# Altium schematic sheet pw_dist_v1.2_s2.SchDoc(pw_dist_v1.2_s2)
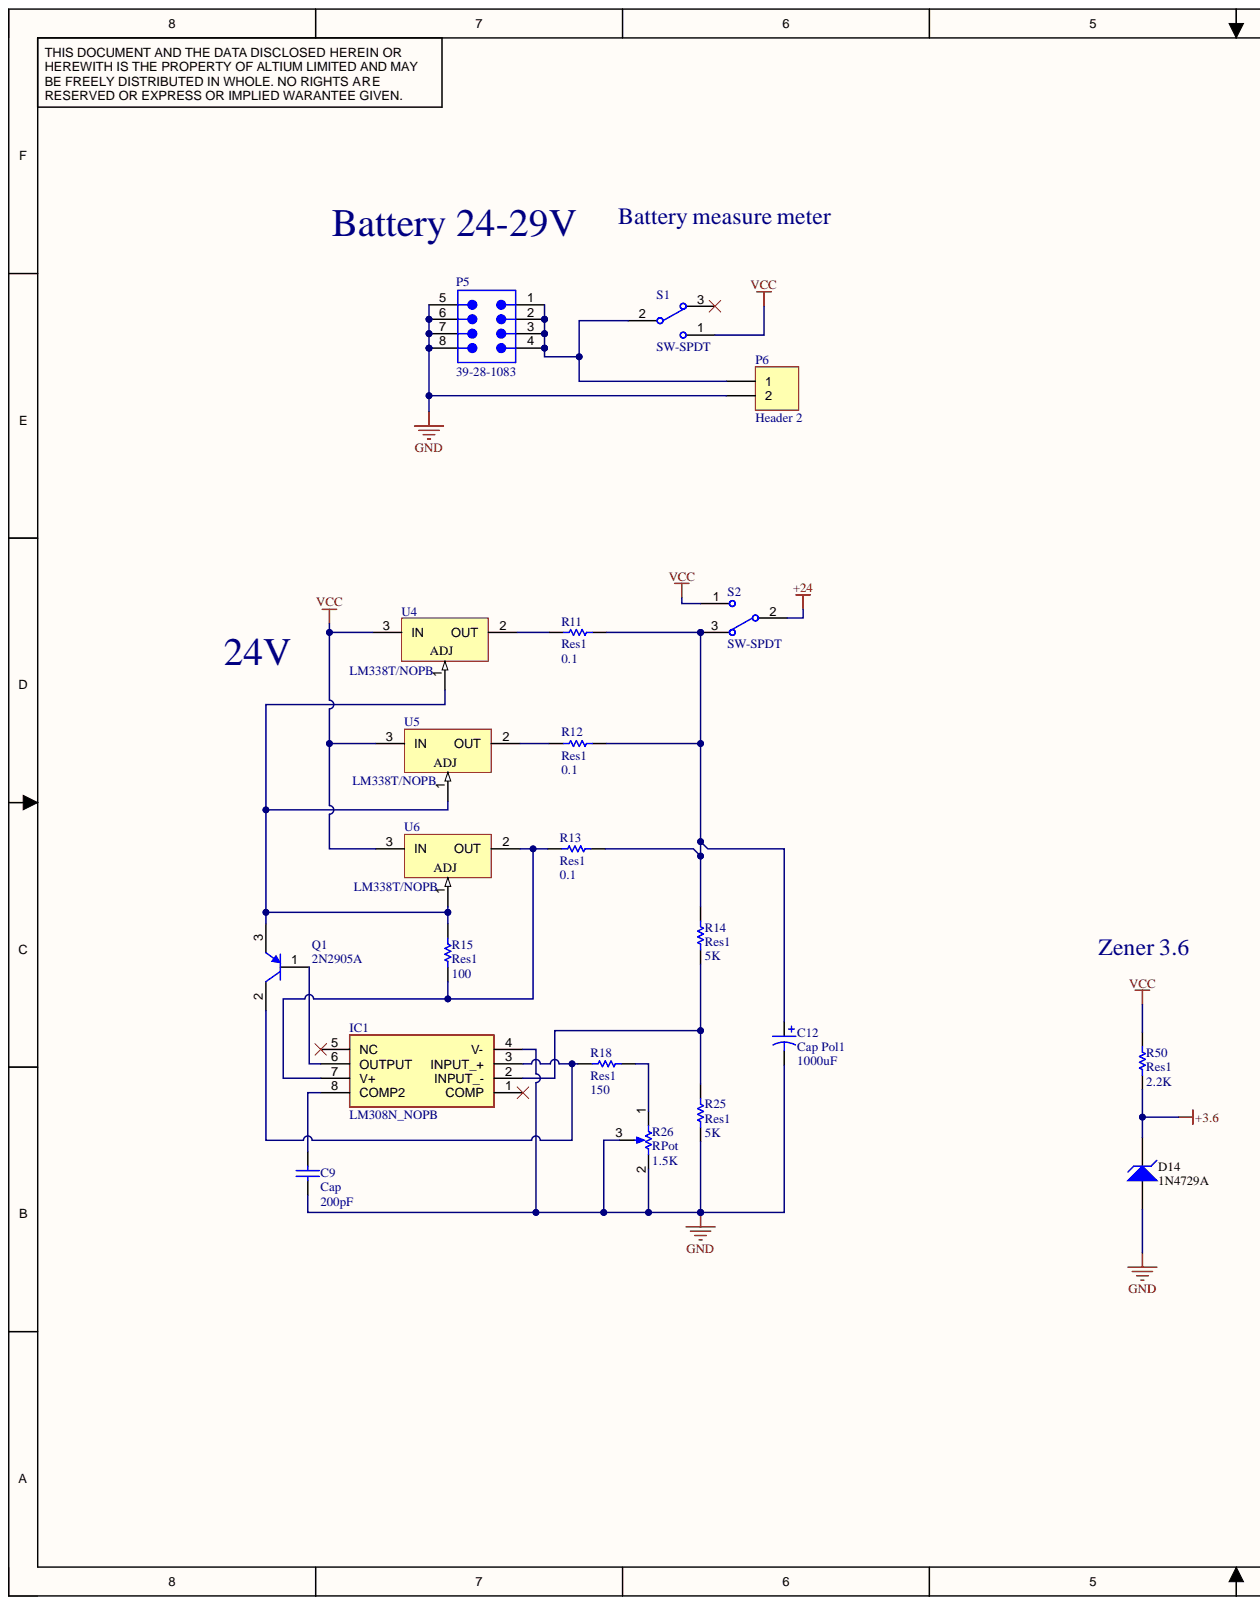
[diagram p0: pw_dist_v1.2_s2.SchDoc, left]
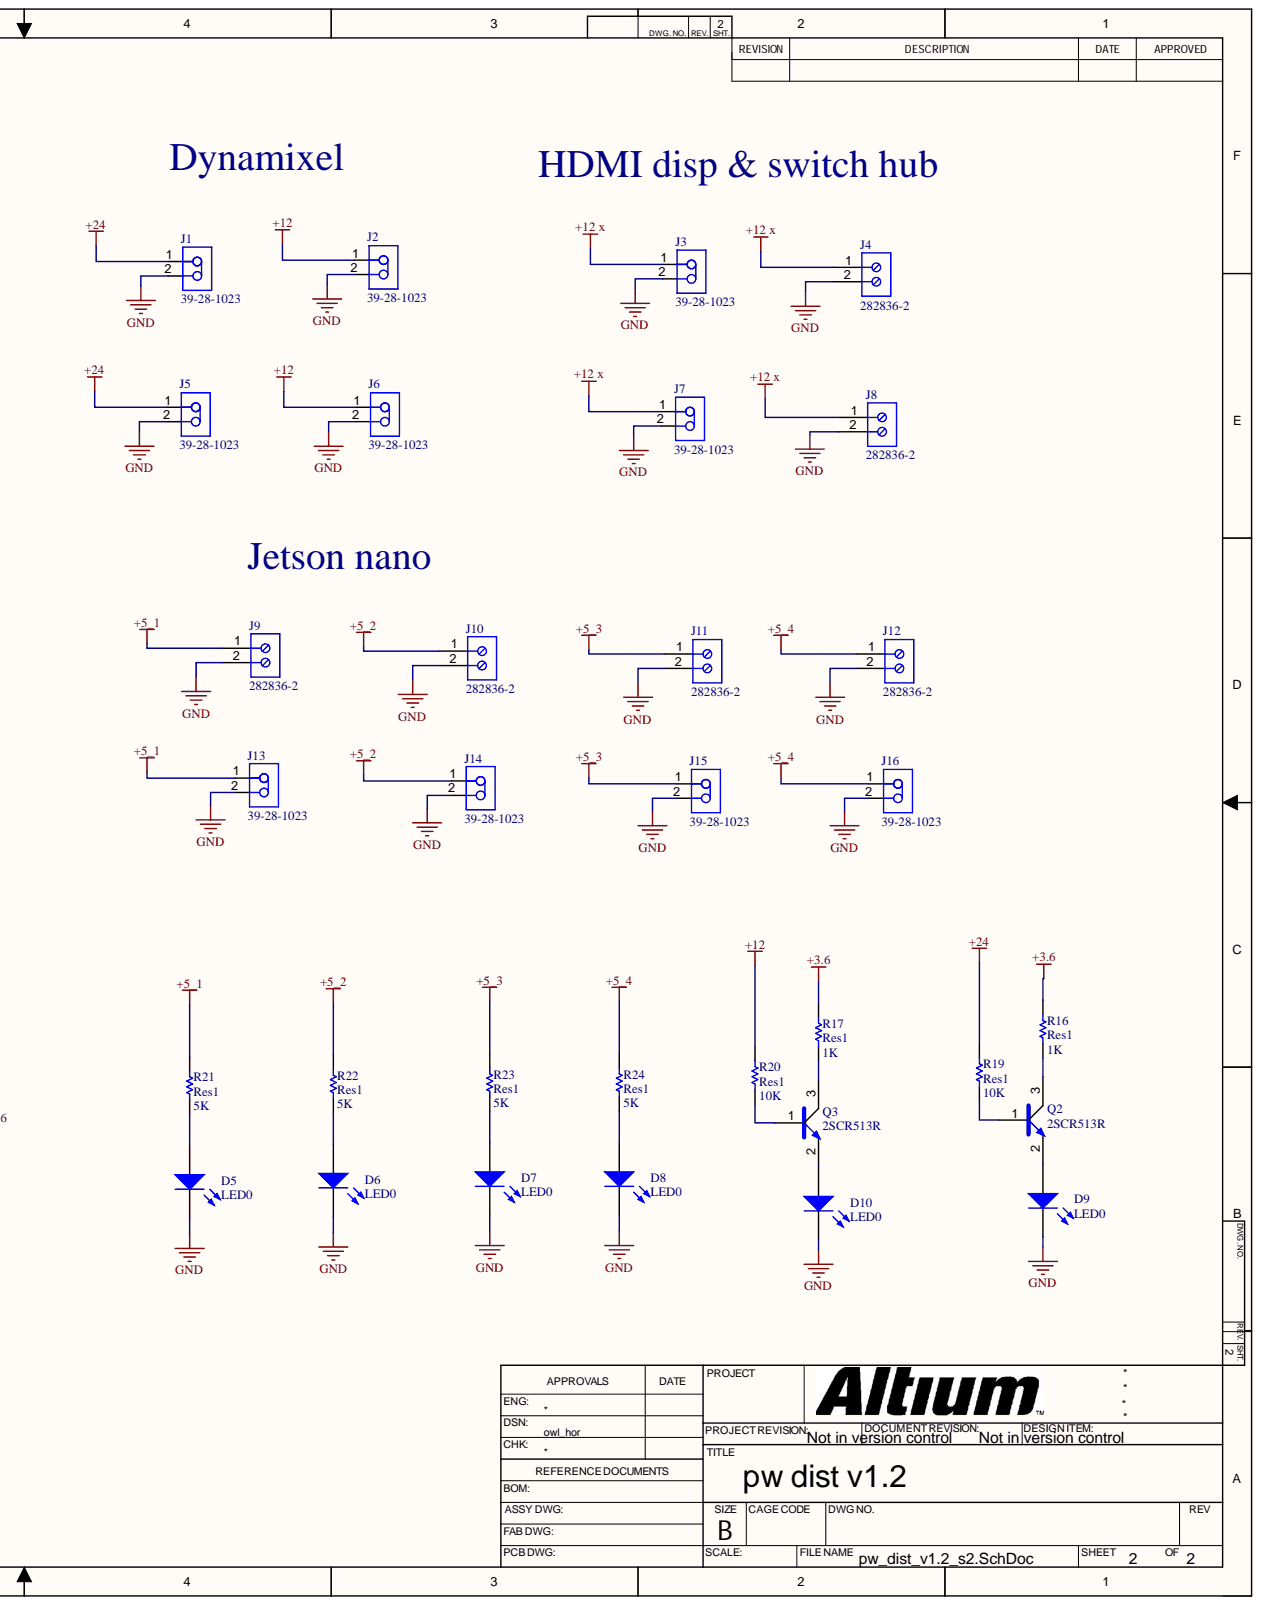
[diagram p1: pw_dist_v1.2_s2.SchDoc, right]

## Parts
C9  Cap  value 200pF  [RAD-0.1]
C12  Cap Pol1  value 1000uF  [RB7.6-15]
D5  LED0  [TY-A1206PG1]
D6  LED0  [TY-A1206PG1]
D7  LED0  [TY-A1206PG1]
D8  LED0  [TY-A1206PG1]
D9  LED0  [TY-A1206PG1]
D10  LED0  [TY-A1206PG1]
D14  1N4729A  [FAIR-DO-41-2_V]
IC1  LM308N_NOPB  [DIP787W53P254L982H508Q8N]
J1  39-28-1023  [MOLX-39-28-1023-2_V]
J2  39-28-1023  [MOLX-39-28-1023-2_V]
J3  39-28-1023  [MOLX-39-28-1023-2_V]
J4  282836-2  [TECO-282836-2_V]
J5  39-28-1023  [MOLX-39-28-1023-2_V]
J6  39-28-1023  [MOLX-39-28-1023-2_V]
J7  39-28-1023  [MOLX-39-28-1023-2_V]
J8  282836-2  [TECO-282836-2_V]
J9  282836-2  [TECO-282836-2_V]
J10  282836-2  [TECO-282836-2_V]
J11  282836-2  [TECO-282836-2_V]
J12  282836-2  [TECO-282836-2_V]
J13  39-28-1023  [MOLX-39-28-1023-2_V]
J14  39-28-1023  [MOLX-39-28-1023-2_V]
J15  39-28-1023  [MOLX-39-28-1023-2_V]
J16  39-28-1023  [MOLX-39-28-1023-2_V]
P5  39-28-1083  [MOLX-39-28-1083_V]
P6  Header 2  [HDR1X2]
Q1  2N2905A  [STM-TO-39-3]
Q2  2SCR513R  [2SCR513R]
Q3  2SCR513R  [2SCR513R]
R11  Res1  value 0.1  [Axial 0.65]
R12  Res1  value 0.1  [Axial 0.65]
R13  Res1  value 0.1  [Axial 0.65]
R14  Res1  value 5K  [Axial 0.65]
R15  Res1  value 100  [AXIAL-0.3]
R16  Res1  value 1K  [Res_SMD_3mm]
R17  Res1  value 1K  [Res_SMD_3mm]
R18  Res1  value 150  [AXIAL-0.3]
R19  Res1  value 10K  [Res_SMD_3mm]
R20  Res1  value 10K  [Res_SMD_3mm]
R21  Res1  value 5K  [Res_SMD_3mm]
R22  Res1  value 5K  [Res_SMD_3mm]
R23  Res1  value 5K  [Res_SMD_3mm]
R24  Res1  value 5K  [Res_SMD_3mm]
R25  Res1  value 5K  [Axial 0.65]
R26  RPot  value 1.5K  [VR5]
R50  Res1  value 2.2K  [AXIAL-0.3]
S1  SW-SPDT  [SS-12F45]
S2  SW-SPDT  [SS-12F45]
U4  LM338T/NOPB  [NDE0003A]
U5  LM338T/NOPB  [NDE0003A]
U6  LM338T/NOPB  [NDE0003A]

## Nets
+3.6: D14.2 R16.2 R17.2 R50.1
+5_1: J9.1 J13.1 R21.2
+5_2: J10.1 J14.1 R22.2
+5_3: J11.1 J15.1 R23.2
+5_4: J12.1 J16.1 R24.2
+12: J2.1 J6.1 R20.2
+12 x: J3.1 J4.1 J7.1 J8.1
+24: J1.1 J5.1 R19.2 S2.2
GND: C9.1 C12.2 D5.2 D6.2 D7.2 D8.2 D9.2 D10.2 D14.1 IC1.4(V-) J1.2 J2.2 J3.2 J4.2 J5.2 J6.2 J7.2 J8.2 J9.2 J10.2 J11.2 J12.2 J13.2 J14.2 J15.2 J16.2 P5.5 P5.6 P5.7 P5.8 P6.2 R25.1 R26.2 R26.3
VCC: R50.2 S1.1 S2.1 U4.3 U5.3 U6.3
NetC9_2: C9.2 IC1.8(COMP2)
NetC12_1: C12.1 R11.1 R12.1 R13.1 R14.2 S2.3
NetD5_1: D5.1 R21.1
NetD6_1: D6.1 R22.1
NetD7_1: D7.1 R23.1
NetD8_1: D8.1 R24.1
NetD9_1: D9.1 Q2.2
NetD10_1: D10.1 Q3.2
NetIC1_2: IC1.2(INPUT_-) R14.1 R25.2
NetIC1_3: IC1.3(INPUT_+) Q1.2 R18.2
NetIC1_6: IC1.6(OUTPUT) Q1.1
NetIC1_7: IC1.7(V+) R13.2 R15.1 U6.2
NetP5_1: P5.1 P5.2 P5.3 P5.4 P6.1 S1.2
NetQ1_3: Q1.3 R15.2 U4.1 U5.1 U6.1
NetQ2_1: Q2.1 R19.1
NetQ2_3: Q2.3 R16.1
NetQ3_1: Q3.1 R20.1
NetQ3_3: Q3.3 R17.1
NetR11_2: R11.2 U4.2
NetR12_2: R12.2 U5.2
NetR18_1: R18.1 R26.1

Not connected: IC1 1(COMP) 5(NC); S1 3

## Library data of the parts
C9 = Cap: Capacitor; Component Kind: Standard; LatestRevisionDate: 17-Jul-2002; LatestRevisionNote: Re-released for DXP Platform.; Library Name: Miscellaneous Devices.IntLib; PackageDescription: Radial Cap, Thru-Hole; 2 Leads; 0.3 in Pin Spacing; PackageReference: RAD-0.3; Published: 8-Jun-2000; Signal Integrity: Cap; Simulation: CAP; Publisher: Altium Limited
C12 = Cap Pol1: Polarized Capacitor (Radial); Component Kind: Standard; LatestRevisionDate: 17-Jul-2002; LatestRevisionNote: Re-released for DXP Platform.; Library Name: Miscellaneous Devices.IntLib; PackageDescription: Polarized Capacitor (Radial); 2 Leads; PackageReference: RB7.6-15; Published: 8-Jun-2000; Signal Integrity: Cap Pol; Simulation: CAP; Publisher: Altium Limited
D5-D10 = LED0: Typical INFRARED GaAs LED; Component Kind: Standard; LatestRevisionDate: 08-Jul-2005; LatestRevisionNote: Mech Layer 1 removed.; Library Name: Miscellaneous Devices.IntLib; PackageDescription: LED; 2 Leads; PackageReference: LED-0; Published: 8-Jun-2000; Simulation: LED0; Publisher: Altium Limited
D14 = CMP-0892-00027-1: Zener Diode, 3.6V, 1W, 5%, -65 to 200 degC, 2-Pin DO-41, Body 2.72 x 5.2 mm, RoHS; Manufacturer: Fairchild Semiconductor; IR (uA): 100; PD (W): 1; Test Current IZ (mA): 69; VZ (Max.) (V): 3.78; VZ (Min.) (V): 3.42; ZZ (Max) (ohm): 10; Component Kind: Standard; ComponentLink1Description: Manufacturer URL; ComponentLink1URL: http://www.fairchildsemi.com/; ComponentLink2Description: Datasheet; ComponentLink2URL: http://www.fairchildsemi.com/ds/1N/1N4744A.pdf; ComponentLink3Description: Package Specification; ComponentLink3URL: http://www.fairchildsemi.com/dwg/DO/DO41A.pdf; DatasheetVersion: Rev. H3; Library Name: Altium Content Vault; Mounting Technology: Through Hole; Operating and Storage Temperature Range: -65 to 200 degC; PackageDescription: 2-Pin Axial leaded, Body 2.72 x 5.2 mm, Lead Spread 25.4 mm; PackageReference: DO-41-2; PackageVersion: Rev. 2; PartNumber: 1N4729A; RoHS: TRUE; Tolerance: 5%
IC1 = LM308N_NOPB: Integrated Circuit; Manufacturer_Name: Texas Instruments; Manufacturer_Part_Number: LM308N/NOPB; Component Kind: Standard; Datasheet Link: http://www.mit.edu/~6.301/LM108.pdf; Height: 5.08mm; Mouser Part Number: N/A; Mouser Price/Stock: https://www.mouser.com/Search/Refine.aspx?Keyword=N%2FA
J1-J3 J5-J7 J13-J16 = CMP-2000-05244-1: Female Header, Pitch 4.2 mm, 1 x 2 Position, Height 12.8 mm, Tail Length 3.5 mm, -40 to 105 degC, RoHS; Manufacturer: Molex; Connector Body Material: –; Connector Mounting: PCB; Connector Type: RectangularPower; Contact Termination: Solder; Current Rating: 13A; Mounting Orientation: Straight; No. of Contacts: 4; No. of Poles: 2; No. of Rows: 1; Pitch Spacing: 4.2mm; Voltage Rating V AC: 600V; Working Voltage: 250V; Colour: Clear; Component Kind: Standard; Connector Insert Type: Header; Connector Mounting Orientation: PCB; Contact Gender: –; Contact Material: Brass; Contact Plating: Tin; Datasheet URL: https://datasheet.ciiva.com/11746/0900766b80460d9e-11746456.pdf; Datasheet Version: Rev. F, 05/2004; Flammability Rating: UL94V-2; Gender: Header; Lead Spacing: 4.2mm; Library Name: Altium Content Vault; Manufacturer URL: http://www.molex.com/; Mounting Type: PCBoard; MSL: –; No. of Ways: 2; Series: Mini-FitJr; SVHC: –; Termination Method: Solder
J4 J8-J12 = CMP-1684-00017-1: Female Header, Pitch 5 mm, 1 x 2 Position, Height 10 mm, Tail Length 3.5 mm, Rohs, Bulk; Manufacturer: TE Connectivity; 3D Model: 282836-2.pdf; Clamp Material - Plating: Brass-NickelPlated; Current: 13.5A; Dynamic Catalog: BuchananSeries; Featured Product: EurostyleTerminalBlockConnectors; Mating Orientation: HorizontalwithBoard; Number of Levels: 1; Other Names: 5ULV-02B  A98076; Pitch: 0.197"(5.00mm); Positions Per Level: 2; Product Training Modules: Wire-to-BoardEurostyleTerminalBlocks; Screw Thread: M3; Torque - Screw: 0.68Nm(6Lb-In); Voltage: 300V; Wire Gauge: 16-30AWG; Wire Termination: Screw-RisingCageClamp; Category: Connectors,Interconnects; Color: Green; Component Kind: Standard; Contact Material - Plating: Brass-TinPlated; Datasheet URL: http://www.te.com.cn/commerce/DocumentDelivery/DDEController?Action=showdoc&DocId=Catalog+Section%7F1308389_EUROSTYLE_TERMINAL_BLOCKS%7F0607%7Fpdf%7FEnglish%7FENG_CS_1308389_EUROSTYLE_TERMINAL_BLOCKS_0607.pdf%7F282836-2; Family: TerminalBlocks-WiretoBoard; Features: Interlocking(Side); Housing Material: Polyamide(PA66),Nylon6/6; Library Name: Altium Content Vault; Manufacturer URL: http://www.te.com; Mounting Type: ThroughHole; Package Description: Female Header, Pitch 5 mm, 1 x 2 Position, Height 10 mm, Tail Length 3.5 mm; Package Reference: THD; Packaging: Bulk; RoHS Information: 282836-2StatementofCompliance; Screw Material - Plating: Brass-NickelPlated; Series: Buchanan; Standard Package: 300
P5 = CMP-1594-00002-1: 4.2 mm Pitch Mini-Fit Jr.Power Connector, Vertical, Through Hole, 8 Circuits, -40 to 105 degC, RoHS, Bag; No. of Positions: 8; No. of Rows: 2; Pitch: 4.2 mm; Component Kind: Standard; ComponentLink1Description: Manufacturer URL; ComponentLink1URL: http://www.molex.com/; ComponentLink2Description: Datasheet; ComponentLink2URL: http://www.molex.com/webdocs/datasheets/pdf/en-us/0039281083_PCB_HEADERS.pdf; ComponentLink3Description: Package Specification; ComponentLink3URL: http://www.molex.com/pdm_docs/sd/039281083_sd.pdf; Library Name: Altium Content Vault; Mounting Technology: Surface Mount; Orientation: Vertical; PackageDescription: 8-Pin PCB Header, Vertical, Pitch 4.2 mm, Through Hole; PackageVersion: Rev. F, 06/2009; Packing: Bag; PartNumber: 39-28-1083; RoHS: TRUE; Temperature Max: 105 degC; Temperature Min: -40 degC
P6 = Header 2: Header, 2-Pin; Component Kind: Standard; LatestRevisionDate: 17-Jul-2002; LatestRevisionNote: Re-released for DXP Platform.; Library Name: Miscellaneous Connectors.IntLib; Signal Integrity: Connector; Publisher: Altium Limited
Q1 = CMP-2000-05560-1: Small Singal PNP Transistor, 200 MHz, -65 to 175 degC, 3-Pin TO-39, RoHS; Manufacturer: STMicroelectronics; Collector Emitter Voltage V(br)ceo: -60V; DC Collector Current: -600mA; DC Current Gain hFE: 50hFE; No. of Pins: 3Pins; Power Dissipation Pd: 600mW; Transition Frequency ft: 200MHz; Automotive Qualification Standard: -; Component Kind: Standard; Datasheet URL: https://datasheet.ciiva.com/15139/getdatasheetpartid-82818-15139087.pdf; Datasheet Version: 02/2003; Library Name: Altium Content Vault; Manufacturer URL: http://www.st.com/; Mounting Technology: ThroughHole; Operating Temperature Max: 175°C; Package Description: 3-Pin Transistor Outlines Package, Dia 9.4 mm, Height 6.6 mm; Package Reference: TO-39-3; Product Range: -; Transistor Case Style: TO-39; Transistor Polarity: PNP
Q2 Q3 = 2SCR513R: NPN 10A 50V Middle power Transistor; Component Kind: Standard; Library Name: Schlib1.SchLib
R11-R25 R50 = Res1: Resistor; Component Kind: Standard; LatestRevisionDate: 17-Jul-2002; LatestRevisionNote: Re-released for DXP Platform.; Library Name: Miscellaneous Devices.IntLib; PackageDescription: Axial Device, Thru-Hole; 2 Leads; 0.3 in Pin Spacing; PackageReference: AXIAL-0.3; Published: 8-Jun-2000; Signal Integrity: Res; Simulation: RESISTOR; Publisher: Altium Limited
R26 = RPot: Potentiometer; Set Position: 0.5; Sim Note: Enter the Resistance value and the set point into parameter fields.; Component Kind: Standard; LatestRevisionDate: 17-Jul-2002; LatestRevisionNote: Re-released for DXP Platform.; Library Name: Miscellaneous Devices.IntLib; PackageDescription: Variable Resistor, Thru-Hole; 3 Leads; PackageReference: VR5; Published: 8-Jun-2000; Simulation: POT; Publisher: Altium Limited
S1 S2 = SW-SPDT: SPDT Subminiature Toggle Switch, Right Angle Mounting, Vertical Actuation; Component Kind: Standard; ComponentLink1Description: Manufacturer Link; ComponentLink1URL: http://www.apem.com; DatasheetVersion: 05-Dec-2000; LatestRevisionDate: 17-Dec-2003; LatestRevisionNote: Schematic revised, PCB Footprint replaced.; Library Name: Miscellaneous Devices.IntLib; PackageDescription: Switch; 4 Leads; PackageReference: TL36WW15050; Published: 24-Mar-1999; Publisher: Altium Limited
U4-U6 = CMP-0062-02474-3: 5 Amp Adjustable Regulator, 3-pin TO-220, Pb-Free; Manufacturer: Texas Instruments; CompType: LDO IC; PackageTopMarking: NSUZXYTTE#*LM338T P+; PowerWise: N; Component Kind: Standard; ComponentLink1Description: Datasheet URL; ComponentLink1URL: http://www.ti.com/general/docs/lit/getliterature.tsp?genericPartNumber=LM338&fileType=pdf; Library Name: Altium Content Vault; PackageDescription: TO-220, Molded, 3 Lead, Straight; PackageReference: NDE0003A; PinCount: 3; RoHS: Y
